# Altium schematic sheet Sheet14.SchDoc(Sheet14)
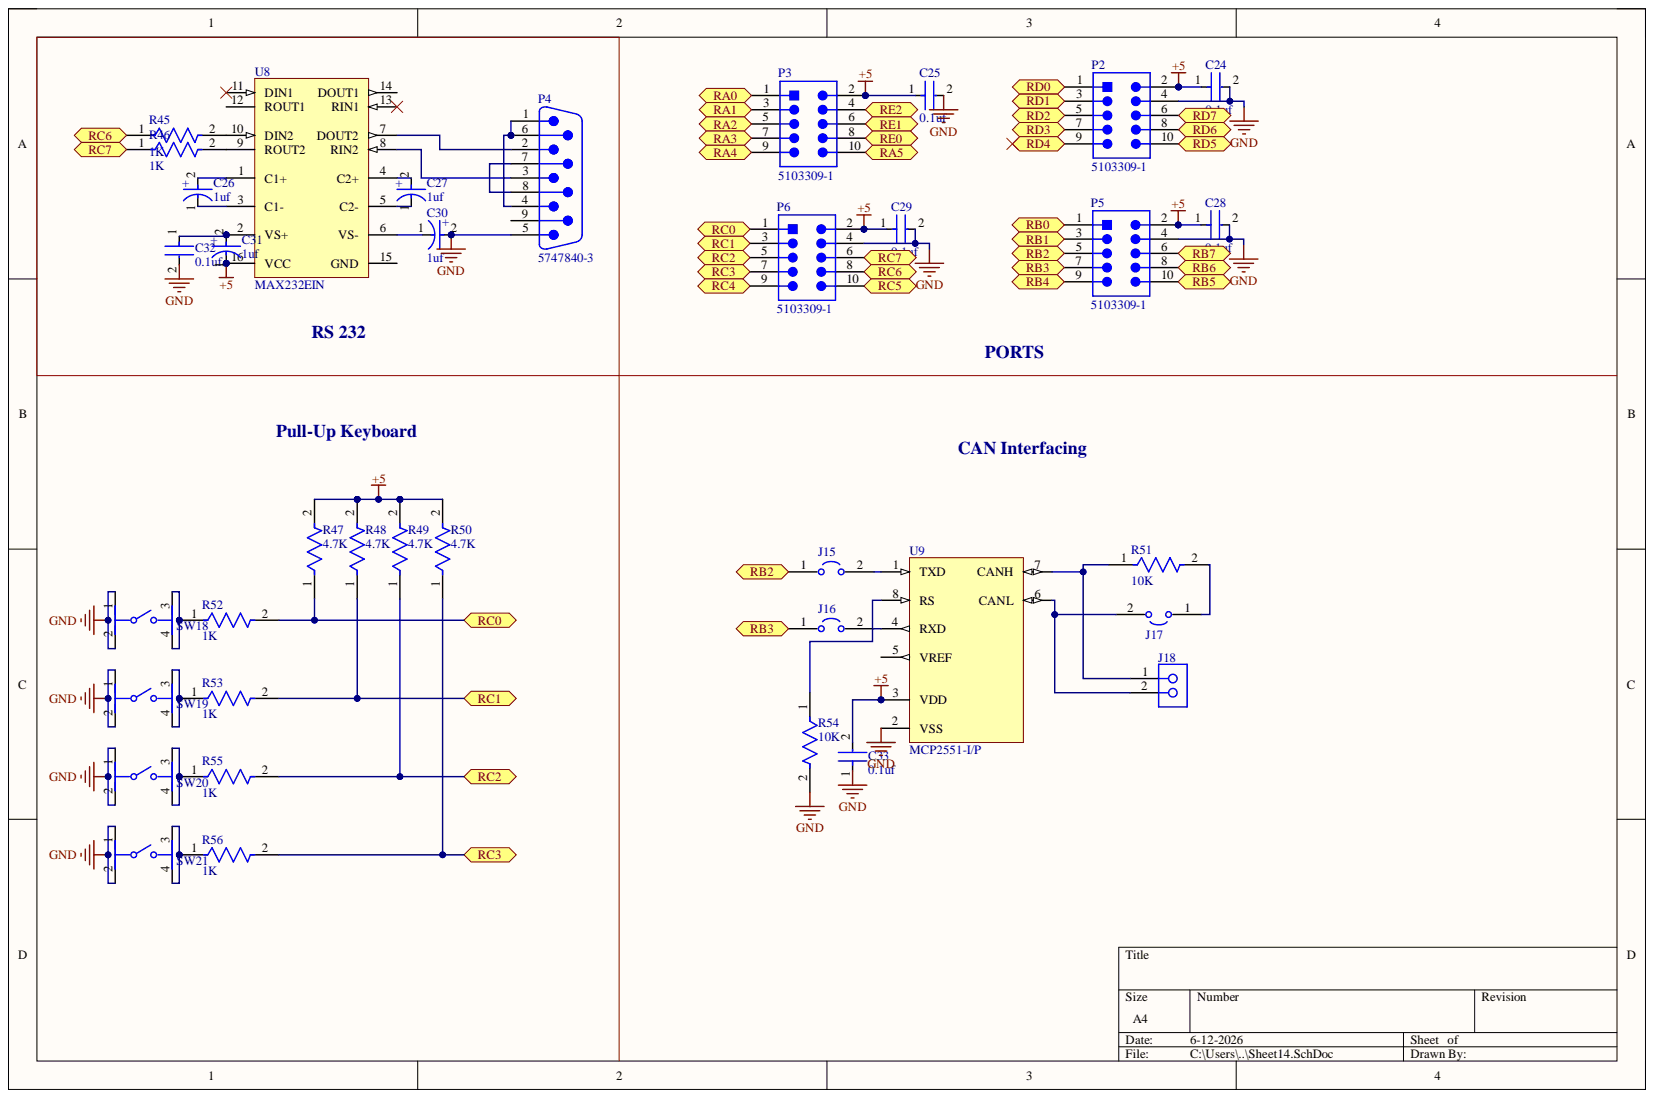
[diagram p0: Sheet14.SchDoc]

## Parts
C24  0.1uf  [FP-C1206C-EB-MFG]
C25  0.1uf  [FP-C1206C-EB-MFG]
C26  1uf  [FP-RAD-TH-D_5_0_5-L_11_1-MFG]
C27  1uf  [FP-RAD-TH-D_5_0_5-L_11_1-MFG]
C28  0.1uf  [FP-C1206C-EB-MFG]
C29  0.1uf  [FP-C1206C-EB-MFG]
C30  1uf  [FP-RAD-TH-D_5_0_5-L_11_1-MFG]
C31  1uf  [FP-RAD-TH-D_5_0_5-L_11_1-MFG]
C32  0.1uf  [FP-C1206C-EB-MFG]
C33  0.1uf  [FP-C1206C-EB-MFG]
J15  S1911-46R  [FP-S1911-46R-MFG]  (value not drawn)
J16  S1911-46R  [FP-S1911-46R-MFG]  (value not drawn)
J17  S1911-46R  [FP-S1911-46R-MFG]  (value not drawn)
J18  691307300002  [691307300002]  (value not drawn)
P2  5103309-1  [TECO-5103309-1_V]
P3  5103309-1  [TECO-5103309-1_V]
P4  5747840-3  [TECO-5747840-3_V]
P5  5103309-1  [TECO-5103309-1_V]
P6  5103309-1  [TECO-5103309-1_V]
R45  1K  [FP-RQ732B-IPC_C]
R46  1K  [FP-RQ732B-IPC_C]
R47  4.7K  [FP-RCMM02-MFG]
R48  4.7K  [FP-RCMM02-MFG]
R49  4.7K  [FP-RCMM02-MFG]
R50  4.7K  [FP-RCMM02-MFG]
R51  10K  [FP-CF18-MFG]
R52  1K  [FP-RQ732B-IPC_C]
R53  1K  [FP-RQ732B-IPC_C]
R54  10K  [FP-CF18-MFG]
R55  1K  [FP-RQ732B-IPC_C]
R56  1K  [FP-RQ732B-IPC_C]
SW18  TL3305AF260QG  [FP-TL3305AF260QG-MFG]  (value not drawn)
SW19  TL3305AF260QG  [FP-TL3305AF260QG-MFG]  (value not drawn)
SW20  TL3305AF260QG  [FP-TL3305AF260QG-MFG]  (value not drawn)
SW21  TL3305AF260QG  [FP-TL3305AF260QG-MFG]  (value not drawn)
U8  MAX232EIN  [N0016A]
U9  MCP2551-I/P  [PDIP300-P8]

## Ports
RA0, RA1, RA2, RA3, RA4, RA5, RB0, RB1, RB2, RB3, RB4, RB5, RB6, RB7, RC0, RC1, RC2, RC3, RC4, RC5, RC6, RC7, RD0, RD1, RD2, RD3, RD4, RD5, RD6, RD7, RE0, RE1, RE2

## Nets
+5: C24.1 C25.1 C28.1 C29.1 C31.1 C33.2 P2.2 P3.2 P5.2 P6.2 R47.2 R48.2 R49.2 R50.2 U8.16(VCC) U9.3(VDD)
GND: C24.2 C25.2 C28.2 C29.2 C30.2 C32.2 C33.1 P2.4 P4.5 P5.4 P6.4 R54.2 SW18.1 SW18.2 SW19.1 SW19.2 SW20.1 SW20.2 SW21.1 SW21.2 U9.2(VSS)
RA0: P3.1 ; port RA0
RA1: P3.3 ; port RA1
RA2: P3.5 ; port RA2
RA3: P3.7 ; port RA3
RA4: P3.9 ; port RA4
RA5: P3.10 ; port RA5
RB0: P5.1 ; port RB0
RB1: P5.3 ; port RB1
RB2: J15.1 P5.5 ; port RB2
RB3: J16.1 P5.7 ; port RB3
RB4: P5.9 ; port RB4
RB5: P5.10 ; port RB5
RB6: P5.8 ; port RB6
RB7: P5.6 ; port RB7
RC0: P6.1 R47.1 R52.2 ; port RC0
RC1: P6.3 R48.1 R53.2 ; port RC1
RC2: P6.5 R49.1 R55.2 ; port RC2
RC3: P6.7 R50.1 R56.2 ; port RC3
RC4: P6.9 ; port RC4
RC5: P6.10 ; port RC5
RC6: P6.8 R45.1 ; port RC6
RC7: P6.6 R46.1 ; port RC7
RD0: P2.1 ; port RD0
RD1: P2.3 ; port RD1
RD2: P2.5 ; port RD2
RD3: P2.7 ; port RD3
RD4: P2.9 ; port RD4
RD5: P2.10 ; port RD5
RD6: P2.8 ; port RD6
RD7: P2.6 ; port RD7
RE0: P3.8 ; port RE0
RE1: P3.6 ; port RE1
RE2: P3.4 ; port RE2
NetC26_1: C26.1 U8.3(C1-)
NetC26_2: C26.2 U8.1(C1+)
NetC27_1: C27.1 U8.5(C2-)
NetC27_2: C27.2 U8.4(C2+)
NetC30_1: C30.1 U8.6(VS-)
NetC31_2: C31.2 C32.1 U8.2(VS+)
NetJ15_2: J15.2 U9.1(TXD)
NetJ16_2: J16.2 U9.4(RXD)
NetJ17_1: J17.1 R51.2
NetJ17_2: J17.2 J18.2 U9.6(CANL)
NetJ18_1: J18.1 R51.1 U9.7(CANH)
NetP4_1: P4.1 P4.4 P4.6
NetP4_2: P4.2 U8.7(DOUT2)
NetP4_3: P4.3 U8.8(RIN2)
NetP4_7: P4.7 P4.8
NetR45_2: R45.2 U8.10(DIN2)
NetR46_2: R46.2 U8.9(ROUT2)
NetR52_1: R52.1 SW18.3 SW18.4
NetR53_1: R53.1 SW19.3 SW19.4
NetR54_1: R54.1 U9.8(RS)
NetR55_1: R55.1 SW20.3 SW20.4
NetR56_1: R56.1 SW21.3 SW21.4

Not connected: P4 9; U8 11(DIN1) 12(ROUT1) 13(RIN1) 14(DOUT1) 15(GND); U9 5(VREF)

## Library data of the parts
C24 C25 C28 C29 C32 C33 = CMP-03020-018297-1: C1206 0.10 μF U2J 20.00% 50 V; Manufacturer: KEMET; Manufacturer Part Number: C1206C104M5JACAUTO; Automotive Grade: Grade 0; Capacitance: 0.10 μF; Material: Ceramic; Temperature Coefficient: 15%; Tolerance: 0.2; Value: 0.10 μF; Voltage Rating: 50 V; Automotive: Yes; Category: Cap; Component Kind: Standard; ComponentLink2Description: Datasheet; ComponentLink2URL: https://content.kemet.com/datasheets/KEM_C1007_X8R_ULTRA_150C_SMD.pdf; Device Class L1: Passive Components; Device Class L2: Capacitors; Device Class L3: Ceramic Capacitors; Height: 0.88mm; Ipc Land Pattern Name: CAPC320160X78; Lead Free: Yes; Library Name: Altium Content Vault; Octopart: https://octopart.com/c1206c104m5jacauto-kemet-84732526; Package: C1206C-EB; RoHS: TRUE; Temperature: -55°C to +150°C; Temperature Characteristic: U2J; Temperature Range High: +150°C; Temperature Range Low: -55°C
C26 C27 C30 C31 = CMP-05427-001266-1: Aluminum Electrolytic Capacitors (Radial Lead Type) 1uF ±20% 50V; Lead Diameter: 0.5mm; Life (Hours): 5000Hour; Ripple Current: 8mA; Capacitance: 1uF; Case/Package: Radial; Component Kind: Standard; Diameter: 5mm; Height: 11mm; Height - Seated (Max): 0.472inch; Lead Pitch: 5mm; Length: 11mm; Library Name: Altium Content Vault; Max Operating Temperature: 105°C; Min Operating Temperature: -40°C; Mount: Through Hole; Package Quantity: 2000; Packaging: Tape and Reel; Radiation Hardening: No; RoHS Compliant: Yes; Series: EB; Termination: Radial; Tolerance: 20%; Voltage Rating: 50V; Voltage Rating (DC): 50V
J15-J17 = CMP-15817-000009-1: JUMPER TIN SMD; Current Rating: 10A; Gender: Non-Gendered; Number of Contacts: 2; Pitch: 0.119inch; Color: Silver; Component Kind: Standard; Contact Material: Brass; Contact Plating: Tin; Depth: 2.51mm; Height: 0.038inch; Length: 6.85mm; Library Name: Altium Content Vault; Material: Brass; Number of Positions: 2; Number of Rows: 1; Orientation: Straight; Package Quantity: 4000; Packaging: Tape and Reel; Plating: Tin; REACH SVHC: No SVHC; RoHS Compliant: Yes; Series: S1; Termination: SMD/SMT
J18 = CMP-1502-01831-3: Terminal Block, Socket, 2Pos, Th Rohs Compliant: Yes; Current: 8A; Gender: Female; Number of Levels: 1; Number of Positions: 2; Pitch: 3.81mm; Voltage: 300V; Color: Green; Component Kind: Standard; Contact Plating: Tin; Lead Pitch: 3.81mm; Library Name: Altium Content Vault; Max Operating Temperature: 115°C; Min Operating Temperature: -40°C; Mount: Through Hole; Number of Pins: 2; Number of Positions Per Level: 2; Orientation: Vertical; Packaging: Box; RoHS: Compliant; Termination: Solder
P2 P3 P5 P6 = CMP-2000-07502-1: Male Header, Pitch 2.54 mm, 2 x 5 Position, Height 9.855 mm, Tail Length 3.048 mm, -65 to 105 degC, RoHS, Tube; Manufacturer: TE Connectivity; Board Standoff: Yes(With); Body Material: Thermoplastic; Connector System: Wire-to-Board; Current Rating: 1A; Insulation Resistance: 5GR; Mating Connector Lock: No(Without); Number of Contacts: 10; PCB Mount Retention: Without; PCB Mounting Orientation: Vertical; Pitch: 2.54mm; Colour: Black; Component Kind: Standard; Connector Type: Header; Contact Gender: Male; Contact Material: CopperAlloy; Contact Mating Length: 0.24inch; Contact Plating: Gold; Contact Shape: Square; Datasheet URL: http://www.te.com/commerce/DocumentDelivery/DDEController?Action=showdoc&DocId=Customer+Drawing%7F5103309%7FE1%7Fpdf%7FEnglish%7FENG_CD_5103309_E1.pdf%7F5103309-1; Datasheet Version: Rev. E1, 03/2011; Depth: 9.14mm; ELV: Compliant; Flammability Rating: UL94V-0; Gender: Male; Height: 8.813mm; Housing Colour: Black; Housing Material: PBT; Lead Free: LeadFree; Length: 0.8inch; Library Name: Altium Content Vault; Manufacturer URL: http://www.te.com/; Mating Alignment: Yes(With); Mating Retention: No(Without); Max Current Rating: 1A; Max Operating Temperature: 105degC; Min Operating Temperature: -65degC; Mount: ThroughHole; Mounting Technology: ThroughHole; Number of Positions: 10; Number of Rows: 2; Orientation: Straight; Package Description: Male Header, Pitch 2.54 mm, 2 x 5 Position, Height 9.855 mm, Tail Length 3.048 mm; Package Quantity: 35; Package Reference: 5103309-1; Packaging: Rail/Tube; Panel Mount Retention: No(Without); PCB Mount Alignment: No(Without); REACH SVHC: NoSVHC; RoHSCompliant: True; Row Spacing: 2.54mm; Shrouded: Yes(With); Shrouded End Dimension: 3.81mm; Termination: ThroughHole; Termination Post Length: 0.12inch
P4 = CMP-2000-05647-1: Male D-Sub Connector, Pitch 2.74 mm, 2 Row, 9 Position, Height 12.93 mm, Tail Length 3.17 mm, -55 to 105 degC, RoHS, Tray; Manufacturer: TE Connectivity; 3D Model: 5747840-3.pdf; Catalog Drawings: AMPD-SubMale.318; Connector Type: Plug,MalePins; Current Rating: 6A; Dynamic Catalog: AMPLIMITEHD-20Series; Featured Product: AMPLIMITED-SubminiatureConnectors; Flange Feature: Housing/Shell(4-40); Mating Products: 5-747905-7-ND  -CONND-SUBRCPT9POSVERTSLD  5-787355-1-ND  -CONNRCPT9POSVERTSOLDERCUP  5746861-4-ND  -CONNRECEPT9POSGOLDIDC  A32510-ND  -CONND-SUBRCPT9POSSLDCUPAU  AFL09B-ND  -CONND-SUBRECEPT9POS30GOLD  A31975-ND  -CONND-SUBRECEPT9P22-26AWGAU  A31974-ND  -CONND-SUBRECEPT9P20-22AWGAU  A31973-ND  -CONND-SUBRECEPT9P26-30AWGAU  A31931-ND  -CONND-SUBRCPT9POS22-26AWGAU; Number of Positions: 9; Other Names: A32091; Product Training Modules: AMPLIMITED-SubConnectors; Voltage Rating: 125V; Category: Connectors,Interconnects; Color: Black; Component Kind: Standard; Connector Style: D-Sub; Contact Finish: Gold; Contact Finish Thickness: Flash; Contact Type: Signal; Datasheet URL: http://www.te.com/commerce/DocumentDelivery/DDEController?Action=srchrtrv&DocNm=5747840&DocType=Customer+Drawing&DocLang=English; Datasheet Version: Rev. D, 01/2013; Family: D-SubConnectors; Features: BoardLock,GroundStrap,GroundingIndents; Library Name: Altium Content Vault; Manufacturer URL: http://www.te.com/; Mounting Technology: ThroughHole; Mounting Type: ThroughHole,RightAngle; Number of Rows: 2; Operating Temperature: -55°C~105°C; Package Description: Male D-Sub Connector, Pitch 2.74 mm, 2 Row, 9 Position, Height 12.93 mm, Tail Length 3.17 mm; Package Reference: 5747840-3; RoHS Information: 5747840-3StatementofCompliance; Series: AMPLIMITEHD-20; Shell Material, Finish: Steel,TinPlated; Shell Size, Connector Layout: 1(DE,E); Standard Package: 130; Termination: Solder
R45 R46 R52 R53 R55 R56 = CMP-03211-006012-1: RQ732B 1206 1KΩ 0.4W 10ppm/°C 0.1% 200V; Manufacturer: TE; Manufacturer Part Number: RQ73C2B1K0BTDF; Automotive Grade: Grade 0; Automotive: Yes; Category: Res; Component Kind: Standard; ComponentLink2Description: Datasheet; ComponentLink2URL: https://www.te.com/commerce/DocumentDelivery/DDEController?Action=showdoc&DocId=Data+Sheet%7F1773270-2%7FA%7Fpdf%7FEnglish%7FENG_DS_1773270-2_A.pdf%7F9-2176388-1149; Composition: Thin Film; Device Class L1: Passive Components; Device Class L2: Resistors; Device Class L3: Chip SMD Resistors; Height: 0.75mm; Ipc Land Pattern Name: RESC310160X60; Lead Free: Yes; Library Name: Altium Content Vault; Octopart: https://octopart.com/rq73c2b1k0btdf-te+connectivity-99292457; Package: RQ732B; Power Rating: 0.4W; Resistance: 1KΩ; RoHS: TRUE; Series: RQ73; Temperature: -55°C to +155°C; Temperature Coefficient: 10ppm/°C; Temperature Range High: +155°C; Temperature Range Low: -55°C; Tolerance: 0.1%; Value: 1KΩ; Voltage Rating: 200V
R47-R50 = CMP-02408-000034-1: RES 4.7K OHM 2% 1/2W AXIAL; Resistance: 4.7kR; Temperature Coefficient: 100ppm/°C; Case/Package: Molded; Component Kind: Standard; Contact Plating: Tin; Diameter: 2.5mm; Length: 6.5mm; Library Name: Altium Content Vault; Mount: Through Hole; Resistor Type: Metal Film; RoHS Compliant: Yes; Tolerance: 2%
R51 R54 = CMP-26528-000002-1: RES 10K OHM 1/8W 5% CF AXIAL; Manufacturer: Stackpole Electronics; Manufacturer Part Number: CF18JT10K0; Power Rating: 0.125W; Resistance: 10kΩ; Temperature Coefficient: 0-500ppm/°C; Value: 10kΩ; Voltage Rating: 250V; Automotive: No; Category: Res; Component Kind: Standard; ComponentLink2Description: Datasheet; ComponentLink2URL: http://www.seielect.com/Catalog/SEI-CF_CFM.pdf; Composition: Carbon Film; Device Class L1: Passive Components; Device Class L2: Resistors; Device Class L3: Through-Hole Resistors; Digikey Description: RES 10K OHM 1/8W 5% CF AXIAL; Height: 2mm; Ipc Land Pattern Name: RESAD656W65L360D200; Lead Free: Yes; Library Name: Altium Content Vault; Octopart: https://octopart.com/cf18jt10k0-stackpole+electronics-19205642; Package: CF18; RoHS: TRUE; Series: CF; Temperature: -55°C to +155°C; Temperature Range High: +155°C; Temperature Range Low: -55°C; Tolerance: 5%
SW18-SW21 = CMP-17879-000010-1: SWITCH TACTILE SPST-NO 50MA 12V; Actuator Type: Standard; Illumination Type: Non-Illuminated; Mechanical Life: 200000Cycles; Operating Force: 260gf; Switch Function: Off-Mom; Switch Travel: 0.2mm; Throw Configuration: SPST; Component Kind: Standard; Lead Free: Lead Free; Library Name: Altium Content Vault; Max Operating Temperature: 70°C; Min Operating Temperature: -20°C; Mount: Surface Mount; Packaging: Tape and Reel; Termination: Gull Wing
U8 = CMP-0299-00016-2: Dual RS-232 Driver / Receiver with IEC61000-4-2 Protection, -40 to 85 degC, 16-Pin PDIP (N),  Pb-Free (RoHS); Drivers Per Package: 2; ESD HBM(kV): +/-15; ICC(Max)(mA): 10; IEC-61000-4-2 SUPPORT: TRUE; Supply Voltage(s)(V): 5; Component Kind: Standard; ComponentLink1Description: Manufacturer URL; ComponentLink1URL: www.ti.com; ComponentLink2Description: Datasheet; ComponentLink2URL: http://www.ti.com/general/docs/lit/getliterature.tsp?genericPartNumber=MAX232E&fileType=pdf; ComponentLink3Description: Package Specification; ComponentLink3URL: http://www.ti.com/litv/pdf/mpdi002c; DatasheetVersion: SLLS723B; Library Name: Altium Content Vault; PackageDescription: 16-Pin Plastic Dual-In-Line Package, Row Spacing 7.62 mm, Pitch 2.54 mm; PackageReference: N0016A; PackageVersion: revE, Dec-2002; Receivers Per Package: 2; RS-232: TRUE; Single Supply: TRUE; SubFamily: RS-232
U9 = CMP-0186-00101-2: High-Speed CAN Transceiver, 8-Pin PDIP, Industrial Temperature; Code_JEDEC: MS-001; Component Kind: Standard; ComponentLink1Description: Manufacturer URL; ComponentLink1URL: http://www.microchip.com/; ComponentLink2Description: Datasheet; ComponentLink2URL: http://ww1.microchip.com/downloads/en/DeviceDoc/21667F.pdf; ComponentLink3Description: Package Specification; ComponentLink3URL: http://www.microchip.com/stellent/groups/techpub_sg/documents/packagingspec/en012702.pdf; DatasheetVersion: revF, Jul-2010; Library Name: Altium Content Vault; PackageDescription: 8-Lead Plastic Dual In-Line (P) - 300 mil Body [PDIP]; PackageReference: PDIP300-P8; PackageVersion: revBB, Aug-2009; RevisionNote: URLs added. DatasheetVersion updated.
